# Altium schematic sheet fist.SchDoc
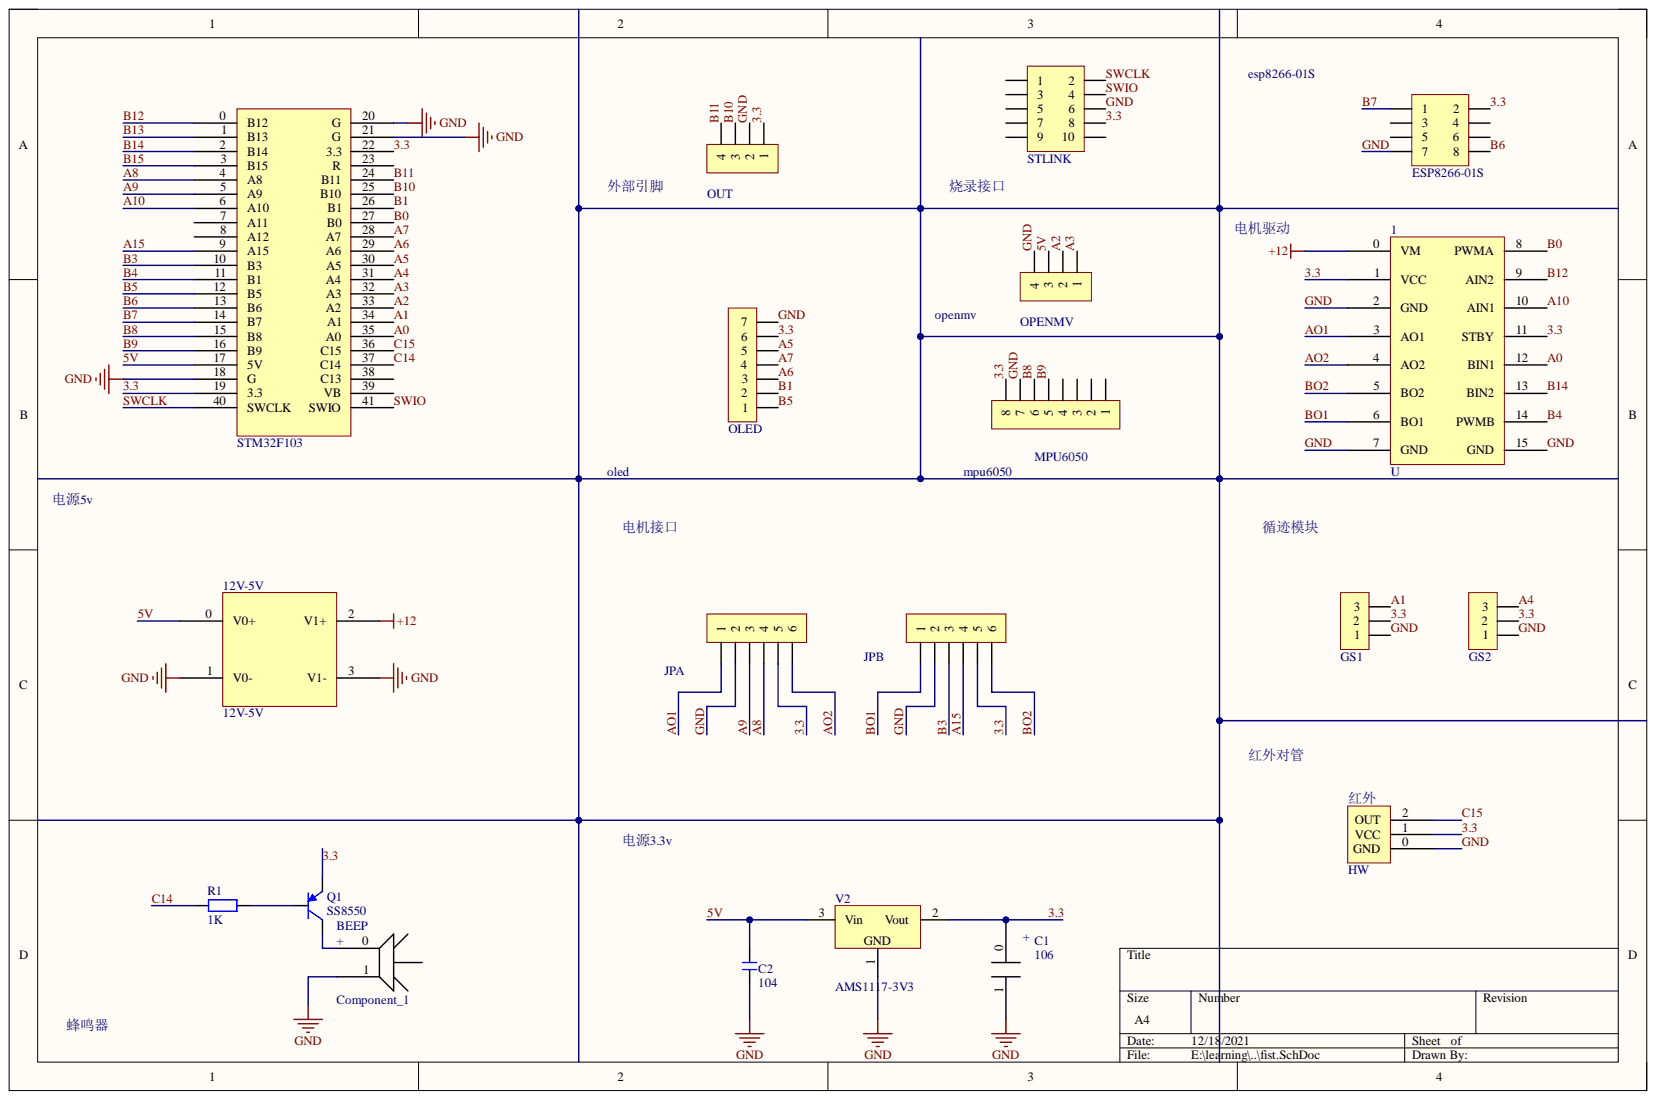
[diagram p0: fist.SchDoc]

## Parts
1  U  [PCBComponent_1]
12V-5V  12V-5V  [PCBComponent_1]
BEEP  Component_1  [PCBComponent_1]
C1  106  [PCBComponent_1]
C2  104  [C 0805_L]
ESP8266-01S  Header 4X2  [IDC2.54-LI-2x4P]  (value not drawn)
GS1  HDR-1X3  [XH2.54-WI-3P]  (value not drawn)
GS2  HDR-1X3  [XH2.54-WI-3P]  (value not drawn)
JPA  HDR-1X6  [XH2.54-WI-6P]  (value not drawn)
JPB  HDR-1X6  [XH2.54-WI-6P]  (value not drawn)
MPU6050  HDR-1X8  [XH2.54-WI-8P]  (value not drawn)
OLED  HDR-1X7  [XH2.54-WI-7P]  (value not drawn)
OPENMV  HDR-1X4  [XH2.54-WI-4P]  (value not drawn)
OUT  HDR-1X4  [XH2.54-WI-4P]  (value not drawn)
Q1  SS8550  [SOT23-3N]
R1  1K  [R 0805_L]
STLINK  Header 5X2  [IDC2.54-LI-2x5P]  (value not drawn)
STM32F103  STM32F103C8T6  [PCBComponent_1]  (value not drawn)
V2  AMS1117-3V3  [SOT223_L]
红外  HW  [PCBComponent_1]

## Nets
+12: 1.0(VM) 12V-5V.2(V1+)
3.3: 1.1(VCC) 1.11(STBY) C1.0 ESP8266-01S.2 GS1.2 GS2.2 JPA.5 JPB.5 MPU6050.8 OLED.6 OUT.1 Q1.2 STLINK.8 STM32F103.19(3.3) STM32F103.22(3.3) V2.2 红外.1
5V: 12V-5V.0(V0+) C2.2 OPENMV.3 STM32F103.17(5V) V2.3
A0: 1.12(BIN1) STM32F103.35(A0)
A1: GS1.3 STM32F103.34(A1)
A2: OPENMV.2 STM32F103.33(A2)
A3: OPENMV.1 STM32F103.32(A3)
A4: GS2.3 STM32F103.31(A4)
A5: OLED.5 STM32F103.30(A5)
A6: OLED.3 STM32F103.29(A6)
A7: OLED.4 STM32F103.28(A7)
A8: JPA.4 STM32F103.4(A8)
A9: JPA.3 STM32F103.5(A9)
A10: 1.10(AIN1) STM32F103.6(A10)
A15: JPB.4 STM32F103.9(A15)
AO1: 1.3(AO1) JPA.1
AO2: 1.4(AO2) JPA.6
B0: 1.8(PWMA) STM32F103.27(B0)
B1: OLED.2 STM32F103.26(B1)
B3: JPB.3 STM32F103.10(B3)
B4: 1.14(PWMB) STM32F103.11(B1)
B5: OLED.1 STM32F103.12(B5)
B6: ESP8266-01S.8 STM32F103.13(B6)
B7: ESP8266-01S.1 STM32F103.14(B7)
B8: MPU6050.6 STM32F103.15(B8)
B9: MPU6050.5 STM32F103.16(B9)
B10: OUT.3 STM32F103.25(B10)
B11: OUT.4 STM32F103.24(B11)
B12: 1.9(AIN2) STM32F103.0(B12)
B13: STM32F103.1(B13)
B14: 1.13(BIN2) STM32F103.2(B14)
B15: STM32F103.3(B15)
BO1: 1.6(BO1) JPB.1
BO2: 1.5(BO2) JPB.6
C14: R1.1 STM32F103.37(C14)
C15: STM32F103.36(C15) 红外.2
GND: 1.2(GND) 1.7(GND) 1.15(GND) 12V-5V.1(V0-) 12V-5V.3(V1-) BEEP.1 C1.1 C2.1 ESP8266-01S.7 GS1.1 GS2.1 JPA.2 JPB.2 MPU6050.7 OLED.7 OPENMV.4 OUT.2 STLINK.6 STM32F103.18(G) STM32F103.20(G) STM32F103.21(G) V2.1 红外.0
SWCLK: STLINK.2 STM32F103.40(SWCLK)
SWIO: STLINK.4 STM32F103.41(SWIO)
NetBEEP_0: BEEP.0 Q1.3
NetQ1_1: Q1.1 R1.2

Not connected: ESP8266-01S 3 4 5 6; MPU6050 1 2 3 4; STLINK 1 3 5 7 9 10; STM32F103 7(A11) 8(A12) 23(R) 38(C13) 39(VB)

## Library data of the parts
1 = TB6612: Component Kind: Standard; Library Name: TB6612.SchLib
C2 = C: 贴片电容; BOM名称: 无极性贴片电容; 淘宝ID: 封装库来自淘宝 ID：黄晶宝石; Component Kind: Standard; Library Name: 01 - 电阻-电容-电感.SchLib; 唯一出售店铺网址: https://shop463601444.taobao.com; 联系售后，技术支持: https://shop463601444.taobao.com
ESP8266-01S = Header 4X2: 4*2P接插件; 版权归属: 封装库来自淘宝 ID：黄晶宝石; BOM名称: 4*2P接插件; Component Kind: Standard; Library Name: 24 - 接插件-脚距2.54 (100mil) .SchLib; 学习资源: https://shop463601444.taobao.com
GS1 GS2 = Header 3: 3P接插件; 版权归属: 封装库来自淘宝 ID：黄晶宝石; BOM名称: 3P接插件; Component Kind: Standard; Library Name: 24 - 接插件-脚距2.54 (100mil) .SchLib; 学习资源: https://shop463601444.taobao.com
JPA JPB = Header 6: 6P接插件; 版权归属: 封装库来自淘宝 ID：黄晶宝石; BOM名称: 6P接插件; Component Kind: Standard; Library Name: 24 - 接插件-脚距2.54 (100mil) .SchLib; 学习资源: https://shop463601444.taobao.com
MPU6050 = Header 8: 8P接插件; 版权归属: 封装库来自淘宝 ID：黄晶宝石; BOM名称: 8P接插件; Component Kind: Standard; Library Name: 24 - 接插件-脚距2.54 (100mil) .SchLib; 学习资源: https://shop463601444.taobao.com
OLED = Header 7: 7P接插件; 版权归属: 封装库来自淘宝 ID：黄晶宝石; BOM名称: 7P接插件; Component Kind: Standard; Library Name: 24 - 接插件-脚距2.54 (100mil) .SchLib; 学习资源: https://shop463601444.taobao.com
OPENMV OUT = Header 4: 4P接插件; 版权归属: 封装库来自淘宝 ID：黄晶宝石; BOM名称: 4P接插件; Component Kind: Standard; Library Name: 24 - 接插件-脚距2.54 (100mil) .SchLib; 学习资源: https://shop463601444.taobao.com
Q1 = 8550-SMD: 高频放大-PNP型; 属性: 40V 1.5A 1W 100MHZ; 版权归属: 封装库来自淘宝 ID：黄晶宝石; BOM名称: 高频放大-PNP型; Component Kind: Standard; Library Name: 04 - 三极管.SchLib; 学习资源: www.ooocke.com
R1 = R: 贴片电阻; 封装库来自淘宝卖家 ID：黄晶宝石: 其他店铺购入均为盗版，不享受售后技术支持服务，请立即申请退款; 版权归属: 来自淘宝 ID：黄晶宝石; BOM名称: 贴片电阻; Component Kind: Standard; Library Name: 01 - 电阻-电容-电感.SchLib; 联系售后: https://shop463601444.taobao.com
STLINK = Header 5X2: 5*2P接插件; 版权归属: 封装库来自淘宝 ID：黄晶宝石; BOM名称: 5*2P接插件; Component Kind: Standard; Library Name: 24 - 接插件-脚距2.54 (100mil) .SchLib; 学习资源: https://shop463601444.taobao.com
V2 = AMS1117: 三端稳压芯片; BOM名称: 稳压芯片; 淘宝ID: 封装库来自淘宝 ID：黄晶宝石; Component Kind: Standard; Library Name: 13 - 电源类芯片.SchLib; 欢迎光临淘宝店铺: https://shop463601444.taobao.com
12V-5V = 12V-5V: Component Kind: Standard; Library Name: 12V-5V.SchLib
BEEP = Component_1: Component Kind: Standard; Library Name: BEEP.SchLib
C1 = 106: Component Kind: Standard; Library Name: 钽电容.SchLib
STM32F103 = STM32F103C8T6: Component Kind: Standard; Library Name: STM32F103C8T6.SchLib
红外 = HW: Component Kind: Standard; Library Name: 红外对管.SchLib
